# Altium schematic sheet Schematic.SchDoc(Schematic)
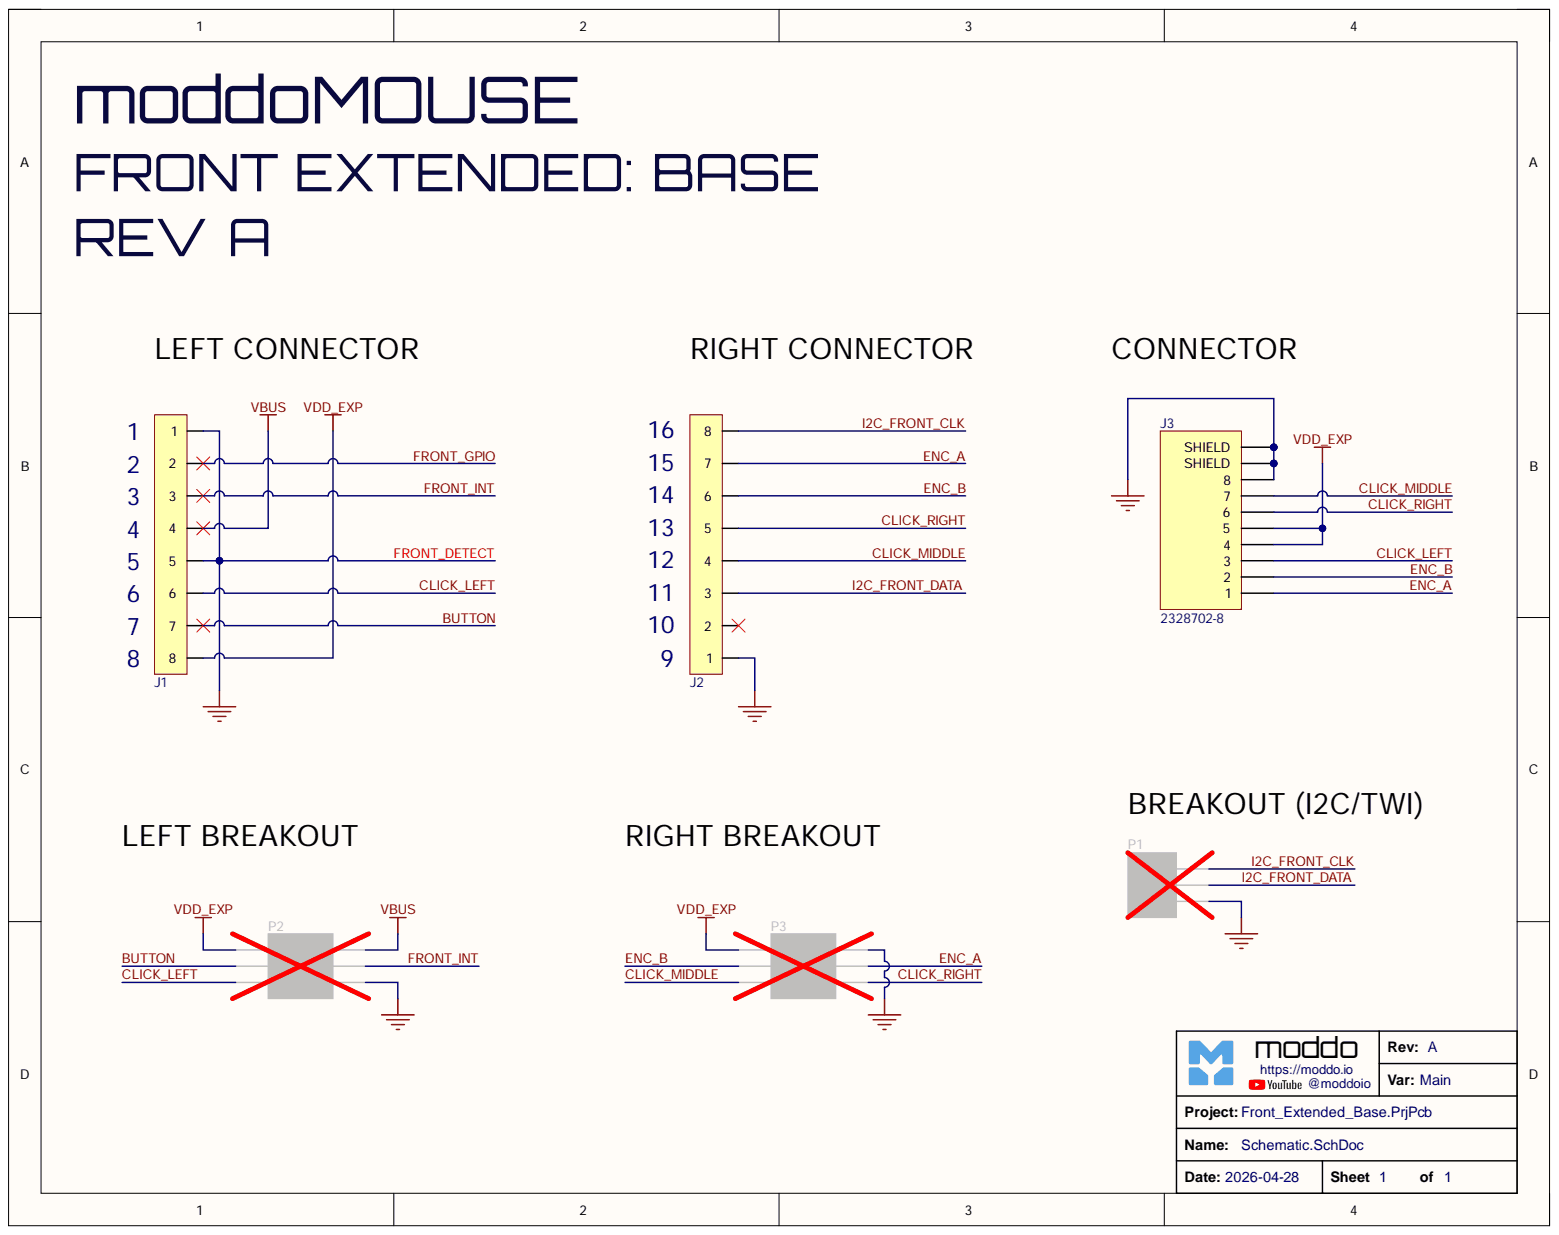
[diagram p0: Schematic.SchDoc]

## Parts
J1  1.27mm_8pins  [1.27mm_8pins_MaleHeader]  (value not drawn)
J2  1.27mm_8pins  [1.27mm_8pins_MaleHeader]  (value not drawn)
J3  2328702-8  [2328702-8]
P1  Header 3  [HDR1X3]  (value not drawn)
P2  Header 3X2  [HDR2X3]  (value not drawn)
P3  Header 3X2  [HDR2X3]  (value not drawn)

## Nets
GND: J1.1 J1.5 J2.1 J3.8 J3.S1(SHIELD) J3.S2(SHIELD) P1.3 P2.6 P3.2
VBUS: J1.4 P2.2
VDD_EXP: J1.8 J3.4 J3.5 P2.1 P3.1
BUTTON: J1.7 P2.3
CLICK_LEFT: J1.6 J3.3 P2.5
CLICK_MIDDLE: J2.4 J3.7 P3.5
CLICK_RIGHT: J2.5 J3.6 P3.6
ENC_A: J2.7 J3.1 P3.4
ENC_B: J2.6 J3.2 P3.3
FRONT_GPIO: J1.2
FRONT_INT: J1.3 P2.4
I2C_FRONT_CLK: J2.8 P1.1
I2C_FRONT_DATA: J2.3 P1.2

Not connected: J2 2

## Library data of the parts
J1 J2 = 1.27mm_8pins: 1.27mm 1.27mm 1A 1 Gold -40℃~+105℃ 8P Phosphor Bronze Through Hole 1x8P Top 4.3mm Square Hole Through Hole,P=1.27mm Female Headers ROHS; Component Kind: Standard; Library Name: MySchematics.SchLib; Manufacturer: N/A; Manufacturer Part Number: N/A
J3 = 2328702-8: FFC/FPC Connector, 8 Contact(s), 1 Row(s), Right Angle, Solder Terminal, Natural Insulator; Manufacturer: TE Connectivity; Actuator Color: Black; Actuator Material: Thermoplastic; Connector System: Wire-to-Board; Gender: Receptacle; Number of Contacts: 8; Operating Supply Voltage: 50V; Plating: Gold; Sealable: No (Without); Category: FFC / FPC; Component Kind: Standard; ComponentLink1Description: https://www.te.com/commerce/DocumentDelivery/DDEController?Action=srchrtrv&amp;DocNm=2328702&amp;DocType=Customer+Drawing&amp;DocLang=English&src-supplier=Digikey; ComponentLink1URL: https://www.te.com/commerce/DocumentDelivery/DDEController?Action=srchrtrv&amp;DocNm=2328702&amp;DocType=Customer+Drawing&amp;DocLang=English&src-supplier=Digikey; Contact Material: Copper Alloy; Contact Plating: Gold; Flammability Rating: UL94 V-0; Height: 1mm; Housing Color: Natural; Housing Material: Thermoplastic; Length: 6mm; Library Name: Connectors.SchLib; Manufacturer Part Number: 2328702-8; Max Operating Temperature: 85°C; Min Operating Temperature: -40°C; Mounting Technology: Surface Mount; Number of Positions: 8; Number of Rows: 1; Orientation: Right Angle; Packaging: Cut Tape; Pricing 1: 28000=0.0961 (USD); RoHS: Yes; Series: -; Stock 1: 56000; Supplier 1: Arrow Electronics; Supplier Part Number 1: 2328702-8; Termination: Solder; Voltage Rating: 50V
P1 = Header 3: Header, 3-Pin; Component Kind: Standard; LatestRevisionDate: 17-Jul-2002; LatestRevisionNote: Re-released for DXP Platform.; Library Name: Miscellaneous Connectors.IntLib; Manufacturer: N/A; Manufacturer Part Number: N/A; Signal Integrity: Connector; Publisher: Altium Limited
P2 P3 = Header 3X2: Header, 3-Pin, Dual row; Component Kind: Standard; LatestRevisionDate: 17-Jul-2002; LatestRevisionNote: Re-released for DXP Platform.; Library Name: Miscellaneous Connectors.IntLib; Manufacturer: N/A; Manufacturer Part Number: N/A; Signal Integrity: Connector; Publisher: Altium Limited
